# Altium schematic sheet Peripherals.SchDoc
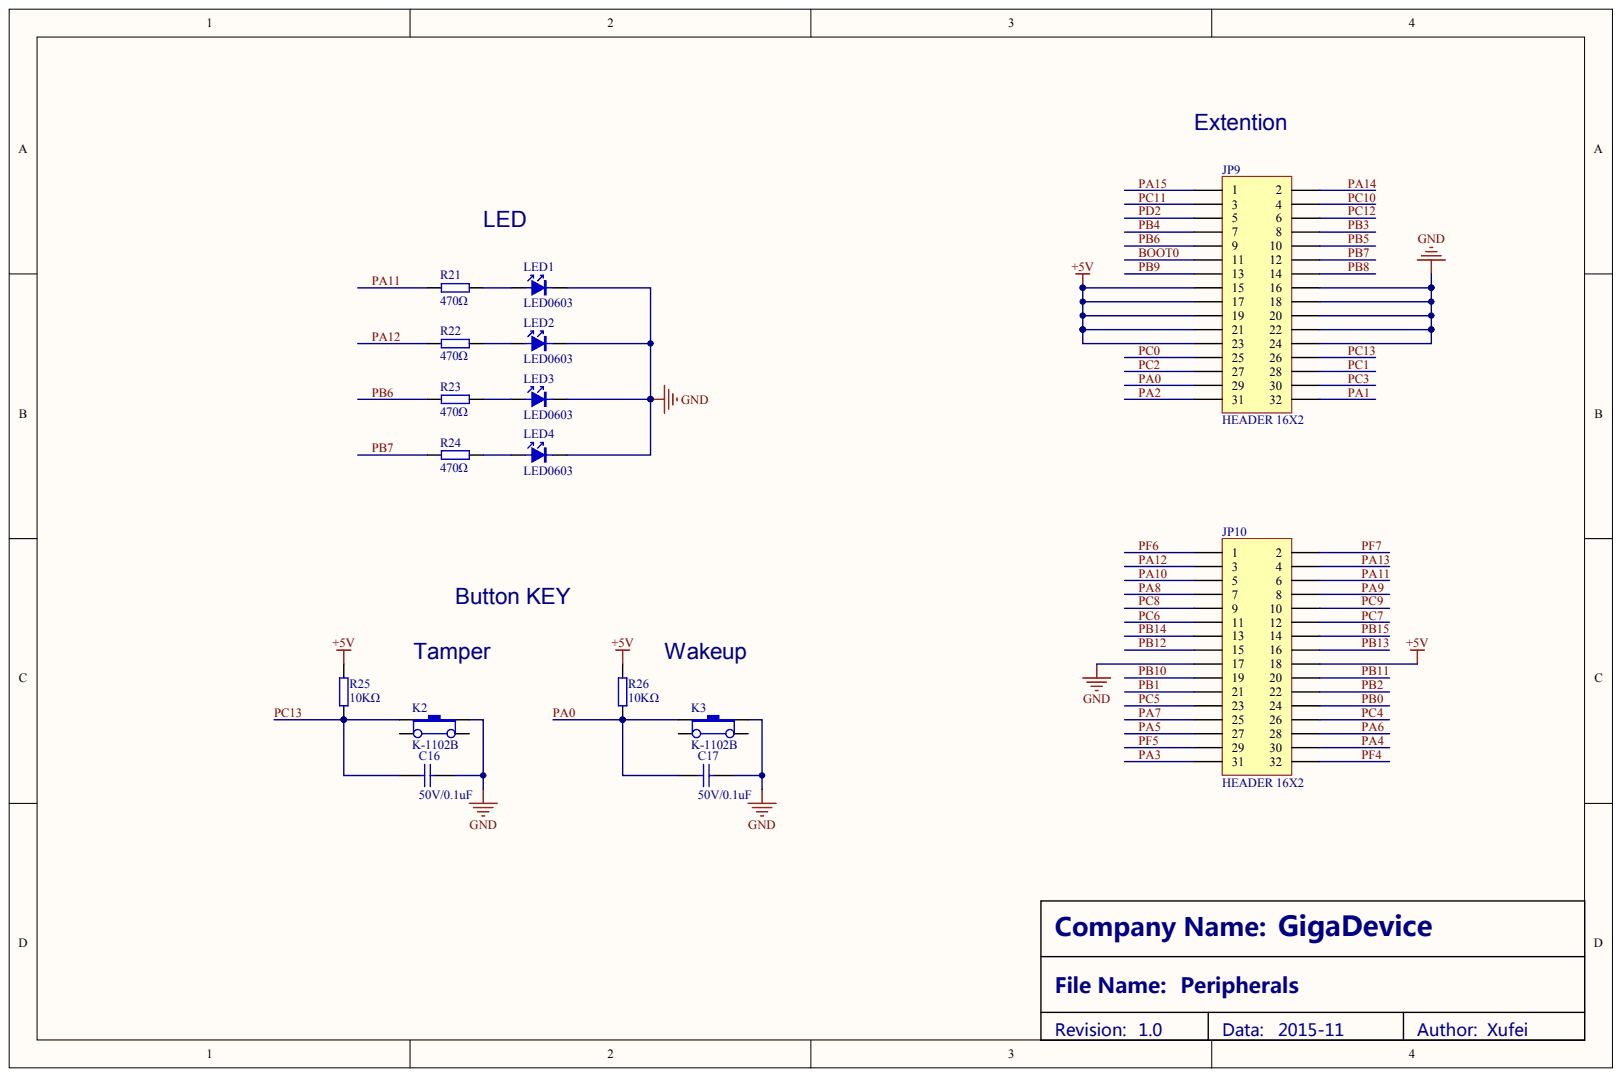
[diagram p0: Peripherals.SchDoc]

## Parts
C16  50V/0.1uF
C17  50V/0.1uF
JP9  HEADER 16X2
JP10  HEADER 16X2
K2  K-1102B
K3  K-1102B
LED1  LED0603
LED2  LED0603
LED3  LED0603
LED4  LED0603
R21  470Ω
R22  470Ω
R23  470Ω
R24  470Ω
R25  10KΩ
R26  10KΩ

## Nets
+5V: JP9.15 JP9.17 JP9.19 JP9.21 JP9.23 JP10.18 R25.1 R26.1
GND: C16.2 C17.2 JP9.16 JP9.18 JP9.20 JP9.22 JP9.24 JP10.17 K2.1 K3.1 LED1.K LED2.K LED3.K LED4.K
BOOT0: JP9.11
PA0: C17.1 JP9.29 K3.3 R26.2
PA1: JP9.32
PA2: JP9.31
PA3: JP10.31
PA4: JP10.30
PA5: JP10.27
PA6: JP10.28
PA7: JP10.25
PA8: JP10.7
PA9: JP10.8
PA10: JP10.5
PA11: JP10.6 R21.1
PA12: JP10.3 R22.1
PA13: JP10.4
PA14: JP9.2
PA15: JP9.1
PB0: JP10.24
PB1: JP10.21
PB2: JP10.22
PB3: JP9.8
PB4: JP9.7
PB5: JP9.10
PB6: JP9.9 R23.1
PB7: JP9.12 R24.1
PB8: JP9.14
PB9: JP9.13
PB10: JP10.19
PB11: JP10.20
PB12: JP10.15
PB13: JP10.16
PB14: JP10.13
PB15: JP10.14
PC0: JP9.25
PC1: JP9.28
PC2: JP9.27
PC3: JP9.30
PC4: JP10.26
PC5: JP10.23
PC6: JP10.11
PC7: JP10.12
PC8: JP10.9
PC9: JP10.10
PC10: JP9.4
PC11: JP9.3
PC12: JP9.6
PC13: C16.1 JP9.26 K2.3 R25.2
PD2: JP9.5
PF4: JP10.32
PF5: JP10.29
PF6: JP10.1
PF7: JP10.2
NetLED1_A: LED1.A R21.2
NetLED2_A: LED2.A R22.2
NetLED3_A: LED3.A R23.2
NetLED4_A: LED4.A R24.2

Not connected: K2 2 4; K3 2 4
